# Altium schematic sheet CurrentSensorBoard.SchDoc
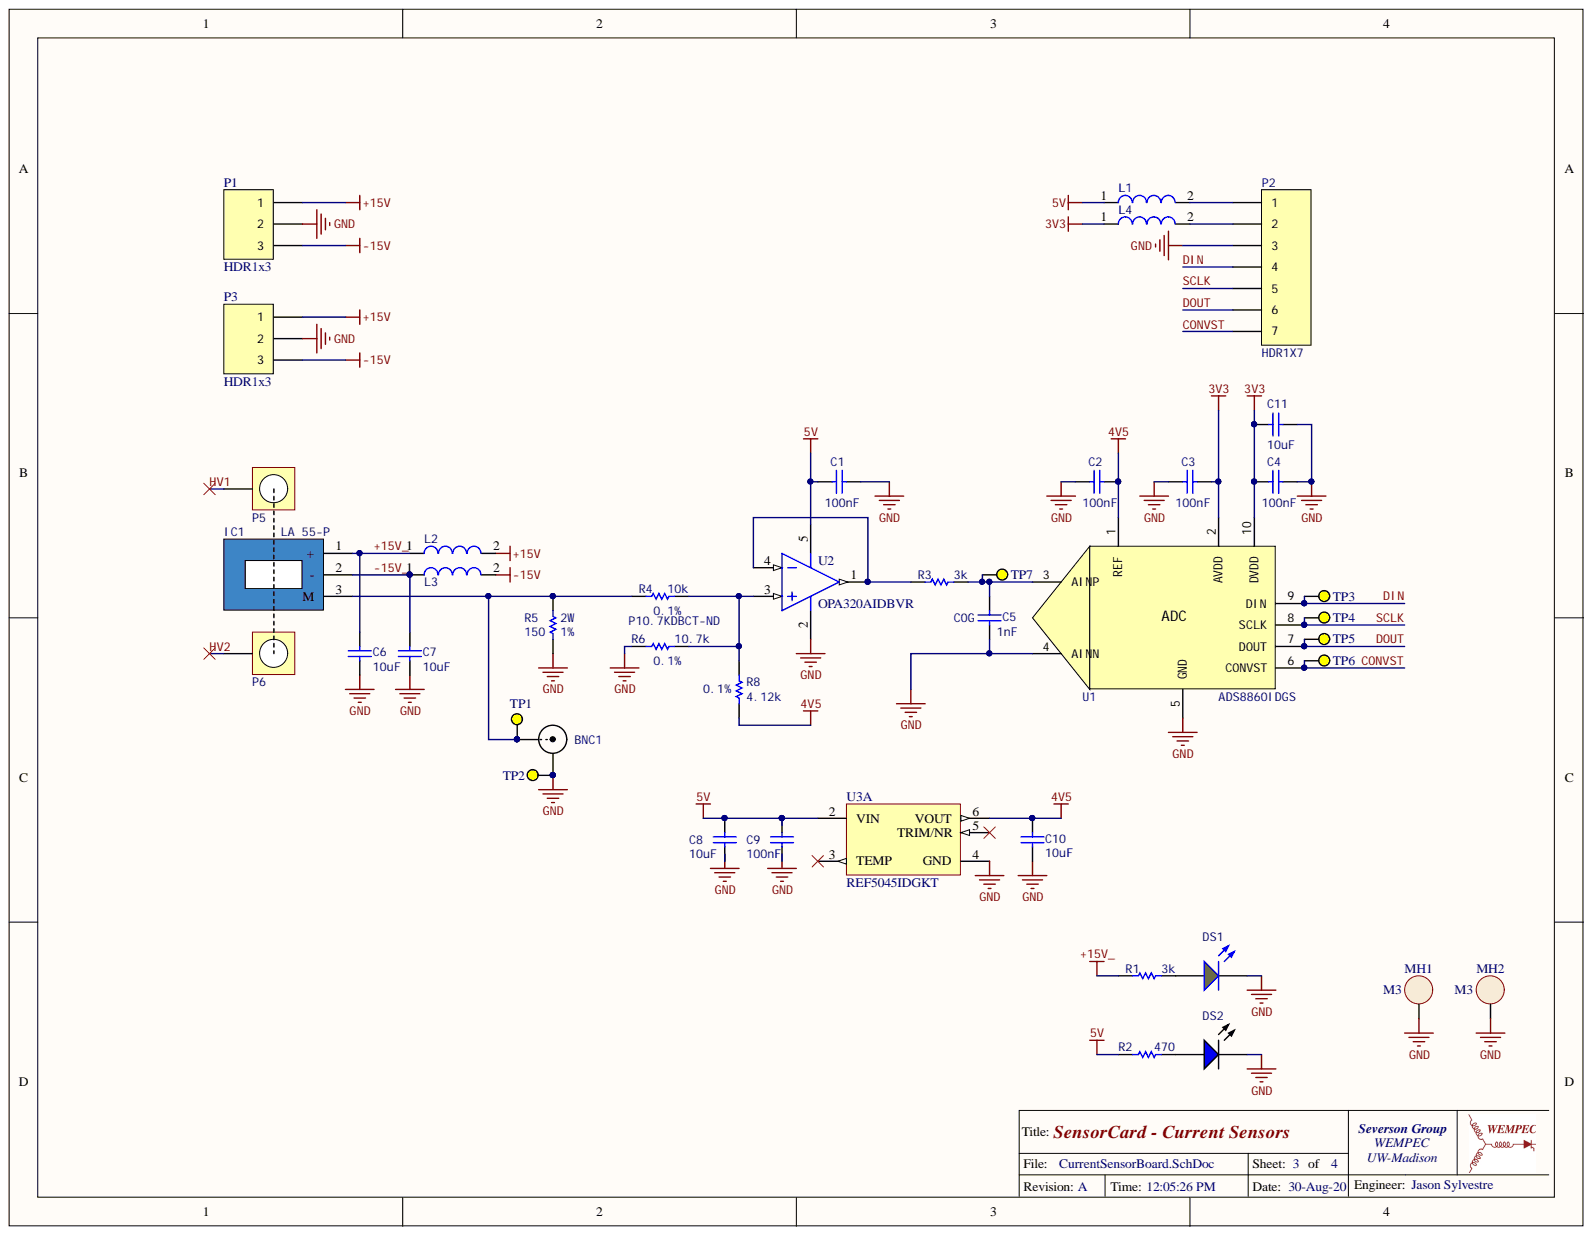
[diagram p0: CurrentSensorBoard.SchDoc]

## Parts
*1  TitleBlockWEMPEC  (value not drawn)
BNC1  BNC  [BNC]
C1  Capacitance: 100nF  [CAPC1608X87X35NL15T15]  (hidden comment: C0603C104Z3VACTU)
C2  Capacitance: 100nF  [CAPC1608X87X35NL15T15]  (hidden comment: C0603C104Z3VACTU)
C3  Capacitance: 100nF  [CAPC1608X87X35NL15T15]  (hidden comment: C0603C104Z3VACTU)
C4  Capacitance: 100nF  [CAPC1608X87X35NL15T15]  (hidden comment: C0603C104Z3VACTU)
C5  Capacitance: 1nF  Dielectric: C0G  [CAPC1608X90X35ML10T15]  (hidden comment: GRM1885C1H102JA01D)
C6  Capacitance: 10uF  [CAP-0805]  (hidden comment: Cap)
C7  Capacitance: 10uF  [CAP-0805]  (hidden comment: Cap)
C8  Capacitance: 10uF  [CAP-0805]  (hidden comment: Cap)
C9  Capacitance: 100nF  [CAPC1608X87X35NL15T15]  (hidden comment: C0603C104Z3VACTU)
C10  Capacitance: 10uF  [CAP-0805]  (hidden comment: Cap)
C11  Capacitance: 10uF  [CAP-0805]  (hidden comment: Cap)
DS1  LTST-C193KGKT-5A  [LTST-C193KGKT-5A_V]  (value not drawn)
DS2  LTST-C193TBKT-5A  [LTST-C193TBKT_V]  (value not drawn)
IC1  LA 55-P  [LA-55P]
L1  742792609  [SMD-0603]  (value not drawn)
L2  742792609  [SMD-0603]  (value not drawn)
L3  742792609  [SMD-0603]  (value not drawn)
L4  742792609  [SMD-0603]  (value not drawn)
MH1  M3  [MOUNTING HOLE - M3]
MH2  M3  [MOUNTING HOLE - M3]
P1  HDR1x3  [Term Block - 3 pos]
P2  HDR1X7  [HDR1x7]
P3  HDR1x3  [HDR1x3]
P5  Screw terminal  [Screw Terminal]  (value not drawn)
P6  Screw terminal  [Screw Terminal]  (value not drawn)
R1  Resistance: 3k  [RESC1608X55X30LL15T15]  (hidden comment: ERJ-3GEYJ302V)
R2  RC0603FR-07470RL  [RESC1608X55X25LL10T15]  (value not drawn)
R3  Resistance: 3k  [RESC1608X55X30LL15T15]  (hidden comment: ERJ-3GEYJ302V)
R4  Resistance: 10k  Tolerance: 0.1%  [RESC1608X55X30ML20T20]  (hidden comment: ERA3AEB103V)
R5  Max Power Dissipation: 2W  Tolerance: 1%  [RES-2512]  (hidden comment: Res 0603)
R6  Resistance: 10.7k  Supplier Part Number 1: P10.7KDBCT-ND  Tolerance: 0.1%  [RESC1608X55X30ML20T20]  (hidden comment: ERA3AEB1072V)
R8  Resistance: 4.12k  Tolerance: 0.1%  [RESC1608X55X30LL20T20]  (hidden comment: ERA3AEB4121V)
TP1  5004  [KSTN-5004]  (value not drawn)
TP2  5004  [KSTN-5004]  (value not drawn)
TP3  5004  [KSTN-5004]  (value not drawn)
TP4  5004  [KSTN-5004]  (value not drawn)
TP5  5004  [KSTN-5004]  (value not drawn)
TP6  5004  [KSTN-5004]  (value not drawn)
TP7  5004  [KSTN-5004]  (value not drawn)
U1  ADC  Manufacturer Part Number: ADS8860IDGS  [VSSOP10]
U2  OPA320AIDBVR  [DBV0005A_L]
U3  REF5045IDGKT  [DGK0008A_N]  (part A)

## Nets
3V3: C3.1 C4.2 C11.2 L4.1 U1.2(AVDD) U1.10(DVDD)
4V5: C2.1 C10.2 R8.1 U1.1(REF) U3.6(VOUT)
5V: C1.1 C8.2 C9.2 L1.1 R2.1 U2.5 U3.2(VIN)
+15V: L2.2 P1.1 P3.1
-15V: L3.2 P1.3 P3.3
GND: BNC1.2 C1.2 C2.2 C3.2 C4.1 C5.1 C6.1 C7.1 C8.1 C9.1 C10.1 C11.1 DS1.2 DS2.2 MH1.1 MH2.1 P1.2 P2.3 P3.2 R5.1 R6.1 TP2.1 U1.4(AINN) U1.5(GND) U2.2 U3.4(GND)
+15V_: C6.2 IC1.1 L2.1 R1.1
-15V_: C7.2 IC1.2 L3.1
CONVST: P2.7 TP6.1 U1.6(CONVST)
DIN: P2.4 TP3.1 U1.9(DIN)
DOUT: P2.6 TP5.1 U1.7(DOUT)
HV1: P5.1
HV2: P6.1
SCLK: P2.5 TP4.1 U1.8(SCLK)
NetBNC1_1: BNC1.1 IC1.3 R4.1 R5.2 TP1.1
NetC5_2: C5.2 R3.2 TP7.1 U1.3(AINP)
NetDS1_1: DS1.1 R1.2
NetDS2_1: DS2.1 R2.2
NetL1_2: L1.2 P2.1
NetL4_2: L4.2 P2.2
NetR3_1: R3.1 U2.1 U2.4
NetR4_2: R4.2 R6.2 R8.2 U2.3

Not connected: U3 3(TEMP) 5(TRIM/NR)
